# Altium schematic sheet LB - MainBoard.SchDoc
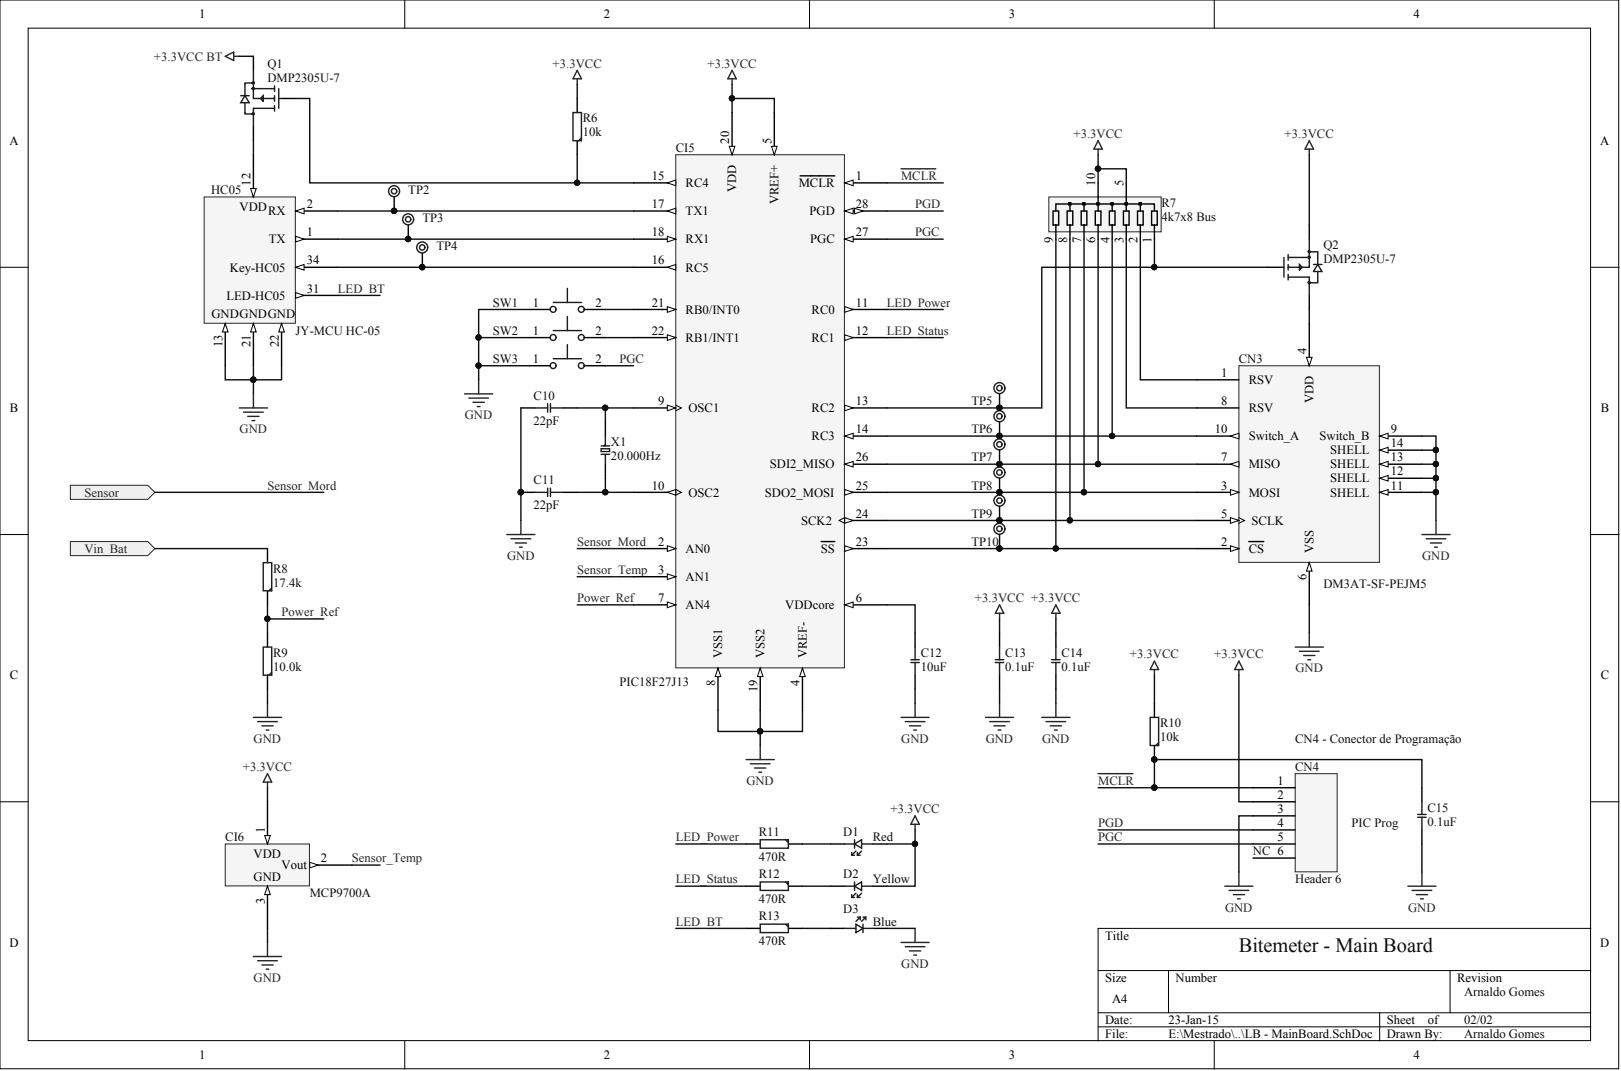
[diagram p0: LB - MainBoard.SchDoc]

## Parts
C10  22pF  [R0805]
C11  22pF  [R0805]
C12  10uF  [R0805]
C13  0.1uF  [R0805]
C14  0.1uF  [R0805]
C15  0.1uF  [R0805]
CI5  PIC18F27J13  [PIC24F16KL402]
CI6  MCP9700A  [MCP9700A]
CN3  DM3AT-SF-PEJM5  [DM3AT]
CN4  Header 6  [HDR1X6]
D1  Red  [LTST-C930]
D2  Yellow  [LTST-C930]
D3  Blue  [LTST-C930]
HC05  JY-MCU HC-05  [JY-MCU HC-05]
Q1  DMP2305U-7  [DMP2305U-7]
Q2  DMP2305U-7  [DMP2305U-7]
R6  10k  [R0805]
R7  4k7x8 Bus  [MMR15 Mod]
R8  17.4k  [R0805]
R9  10.0k  [R0805]
R10  10k  [R0805]
R11  470R  [R0805]
R12  470R  [R0805]
R13  470R  [R0805]
SW1  (no value)  [Push Button2]
SW2  (no value)  [Push Button2]
SW3  (no value)  [Push Button2]
TP2  TP  [TPSMD]  (no BOM)
TP3  TP  [TPSMD]  (no BOM)
TP4  TP  [TPSMD]  (no BOM)
TP5  TP  [TPSMD]  (no BOM)
TP6  TP  [TPSMD]  (no BOM)
TP7  TP  [TPSMD]  (no BOM)
TP8  TP  [TPSMD]  (no BOM)
TP9  TP  [TPSMD]  (no BOM)
TP10  TP  [TPSMD]  (no BOM)
X1  20.000Hz  [ABM3]

## Ports
SENSOR, VIN_BAT

## Nets
+3.3VCC: C13.2 C14.2 CI5.5(VREF+) CI5.20(VDD) CI6.1 CN4.2 D1.A D2.A Q2.S R6.2 R7.5 R7.10 R10.2
+3.3VCC BT: Q1.S
GND: C10.2 C11.2 C12.1 C13.1 C14.1 C15.1 CI5.4(VREF-) CI5.8(VSS1) CI5.19(VSS2) CI6.3 CN3.6(VSS) CN3.9(Switch_B) CN3.11(SHELL) CN3.12(SHELL) CN3.13(SHELL) CN3.14(SHELL) CN4.3 D3.K HC05.13 HC05.21 HC05.22 R9.1 SW1.1 SW2.1 SW3.1
LED_BT: HC05.31(LED-HC05) R13.2
LED_POWER: CI5.11(RC0) R11.2
LED_STATUS: CI5.12(RC1) R12.2
~{MCLR}: C15.2 CI5.1(MCLR) CN4.1 R10.1
PGC: CI5.27(PGC) CN4.5 SW3.2
PGD: CI5.28(PGD) CN4.4
POWER_REF: CI5.7(AN4) R8.1 R9.2
SENSOR_MORD: CI5.2(AN0) ; port SENSOR
SENSOR_TEMP: CI5.3(AN1) CI6.2
NetC10_1: C10.1 CI5.9(OSC1) X1.1
NetC11_1: C11.1 CI5.10(OSC2) X1.2
NetC12_2: C12.2 CI5.6(VDDcore)
NetCI5_13: CI5.13(RC2) Q2.G R7.1 TP5.1
NetCI5_14: CI5.14(RC3) CN3.10(Switch_A) R7.4 TP6.1
NetCI5_15: CI5.15(RC4) Q1.G R6.1
NetCI5_16: CI5.16(RC5) HC05.34(Key-HC05) TP4.1
NetCI5_17: CI5.17(TX1) HC05.2(VDD_RX) TP2.1
NetCI5_18: CI5.18(RX1) HC05.1(TX) TP3.1
NetCI5_21: CI5.21(RB0/INT0) SW1.2
NetCI5_22: CI5.22(RB1/INT1) SW2.2
NetCI5_23: CI5.23(SS) CN3.2(CS) R7.9 TP10.1
NetCI5_24: CI5.24(SCK2) CN3.5(SCLK) R7.8 TP9.1
NetCI5_25: CI5.25(SDO2_MOSI) CN3.3(MOSI) R7.7 TP8.1
NetCI5_26: CI5.26(SDI2_MISO) CN3.7(MISO) R7.6 TP7.1
NetCN3_1: CN3.1(RSV) R7.2
NetCN3_4: CN3.4(VDD) Q2.D
NetCN3_8: CN3.8(RSV) R7.3
NetD1_K: D1.K R11.1
NetD2_K: D2.K R12.1
NetD3_A: D3.A R13.1
NetHC05_12: HC05.12 Q1.D
NetR8_2: R8.2 ; port VIN_BAT

Not connected: CN4 6

## Library data of the parts
C10 C11 = C: Capacitor 22pF; PN_Fab: GQM2195C1H220JB01D; PN_For: 490-3608-2-ND; Ref_Fab: Murata Electronics North America; Ref_For: Digikey; Component Kind: Standard; Library Name: LB - V01-R01.SchLib
C12 = C: Capacitor 10uFx6.3V; PN_Fab: GRM21BR60J106ME19L; PN_For: 490-1718-1-ND; Ref_Fab: Murata Electronics North America; Ref_For: Digikey; Component Kind: Standard; Library Name: LB - V01-R01.SchLib
C13-C15 = C: Capacitor 0.1uFx25V; PN_Fab: C2012X7R1H104K085AA; PN_For: 445-7534-1-ND; Ref_Fab: Murata Electronics North America; Ref_For: Digikey; Component Kind: Standard; Library Name: LB - V01-R01.SchLib
CI5 = PIC18F27J13: PIC18 8Bits; PN_Fab: PIC18F27J13-I/SO; PN_For: PIC18F27J13-I/SO-ND; Ref_Fab: Microchip Technology; Ref_For: Digikey; Component Kind: Standard; Library Name: LB - V01-R01.SchLib
CI6 = MCP9700A: Sensor de temperatura; PN_Fab: MCP9700AT-E/TT; PN_For: MCP9700AT-E/TTCT-ND; Ref_Fab: Microchip; Ref_For: Digikey; Component Kind: Standard; Library Name: LB - V01-R01.SchLib
CN3 = MicroSD - DM3CS-SF: Slot Micro SD - Push-Pull; PN_For: HR1964CT-ND; Ref_Fab: Hirose Electric Co Ltd; Ref_For: Digikey; Component Kind: Standard; Library Name: LB - V01-R01.SchLib; PN_Fab: DM3AT-SF-PEJM5
CN4 = Conector Programação: Header, 6-Pin; PN_Fab: 534237-4; PN_For: A26418-ND; Ref_Fab: TE Connectivity; Ref_For: Digikey; Component Kind: Standard; Library Name: LB - V01-R01.SchLib
D1 = LED: PN_Fab: LTST-C930KRKT; PN_For: 160-1463-2-ND; Ref_Fab: Lite-On Inc; Ref_For: Digikey; Component Kind: Standard; Description: LED; Library Name: LB - V01-R01.SchLib
D2 = LED: PN_Fab: LTST-C930KSKT; PN_For: 160-1464-1-ND; Ref_Fab: Lite-On Inc; Ref_For: Digikey; Component Kind: Standard; Description: LED; Library Name: LB - V01-R01.SchLib
D3 = LED: PN_Fab: LTST-C930TBKT; PN_For: 160-1649-1-ND; Ref_Fab: Lite-On Inc; Ref_For: Digikey; Component Kind: Standard; Description: LED; Library Name: LB - V01-R01.SchLib
HC05 = JY-MCU HC-05: Modulo Bluetooth HC-05; Ref_For: Deal Extreme; Component Kind: Standard; Library Name: LB - V01-R01.SchLib; PN_Fab: JY-MCU HC-05; PN_For: JY-MCU HC-05
Q1 = MOSFET-P DIODE: MOSFET-P; PN_For: DMP2305UDICT-ND; Ref_Fab: Diodes Incorporated; Ref_For: Digikey; Component Kind: Standard; Library Name: LB - V01-R01.SchLib; PN_Fab: DMP2305U-7
Q2 = MOSFET-P DIODE: MOSFET-P; PrjPn: P1301-0086; Ref1_Fab: Diodes Inc; Ref1_For: Digikey; Ref1_ForPn: DMP2305UDICT-ND; Component Kind: Standard; Library Name: PCB1301-R00C.SchLib; Ref1_Pn: DMP2305U-7
R6 R10 = R: PN_Fab: MCR10ERTJ103; PN_For: RHM10KCPCT-ND; Ref_Fab: Rohm Semiconductor; Ref_For: Digikey; Component Kind: Standard; Library Name: LB - V01-R01.SchLib
R7 = R ARRAY BUS 8 - 10 PIN: Brigde 4k7x8; PN_Fab: MNR15ERRPJ472; PN_For: RHM1615CT-ND; Ref_Fab: Rohm Semiconductor; Ref_For: Digikey; Component Kind: Standard; Library Name: LB - V01-R01.SchLib
R8 = R: PN_Fab: KTR10EZPF1742; PN_For: RHM17.4KAHCT-ND; Ref_Fab: Rohm Semiconductor; Ref_For: Digikey; Component Kind: Standard; Library Name: LB - V01-R01.SchLib
R9 = R: PN_Fab: MCR10ERTF1002; PN_For: RHM10.0KCHCT-ND; Ref_Fab: Rohm Semiconductor; Ref_For: Digikey; Component Kind: Standard; Library Name: LB - V01-R01.SchLib
R11 R12 = R: PN_Fab: MCR10ERTJ471; PN_For: RHM470CPCT-ND; Ref_Fab: Rohm Semiconductor; Ref_For: Digikey; Component Kind: Standard; Library Name: LB - V01-R01.SchLib
R13 = R: PN_Fab: MCR10ERTJ471; PN_For: RHM220CPCT-ND; Ref_Fab: Rohm Semiconductor; Ref_For: Digikey; Component Kind: Standard; Library Name: LB - V01-R01.SchLib
SW1-SW3 = Push button: PN_Fab: FSM6JSMA; PN_For: 450-1133-ND; Ref_Fab: TE Connectivity; Ref_For: Digikey; Component Kind: Standard; Description: Push Button; Library Name: LB - V01-R01.SchLib
TP2-TP10 = TP: Test Point; Library Name: LB - V01-R01.SchLib
X1 = XTAL: Crystal 20.000Hz; PN_Fab: ABM3-20.000MHZ-B2-T; PN_For: 535-9105-1-ND; Ref_Fab: Abracon Corporation; Ref_For: Digikey; Component Kind: Standard; Library Name: LB - V01-R01.SchLib
